# Altium schematic sheet OpenSwitch_Power.SchDoc(OpenSwitch_Power)
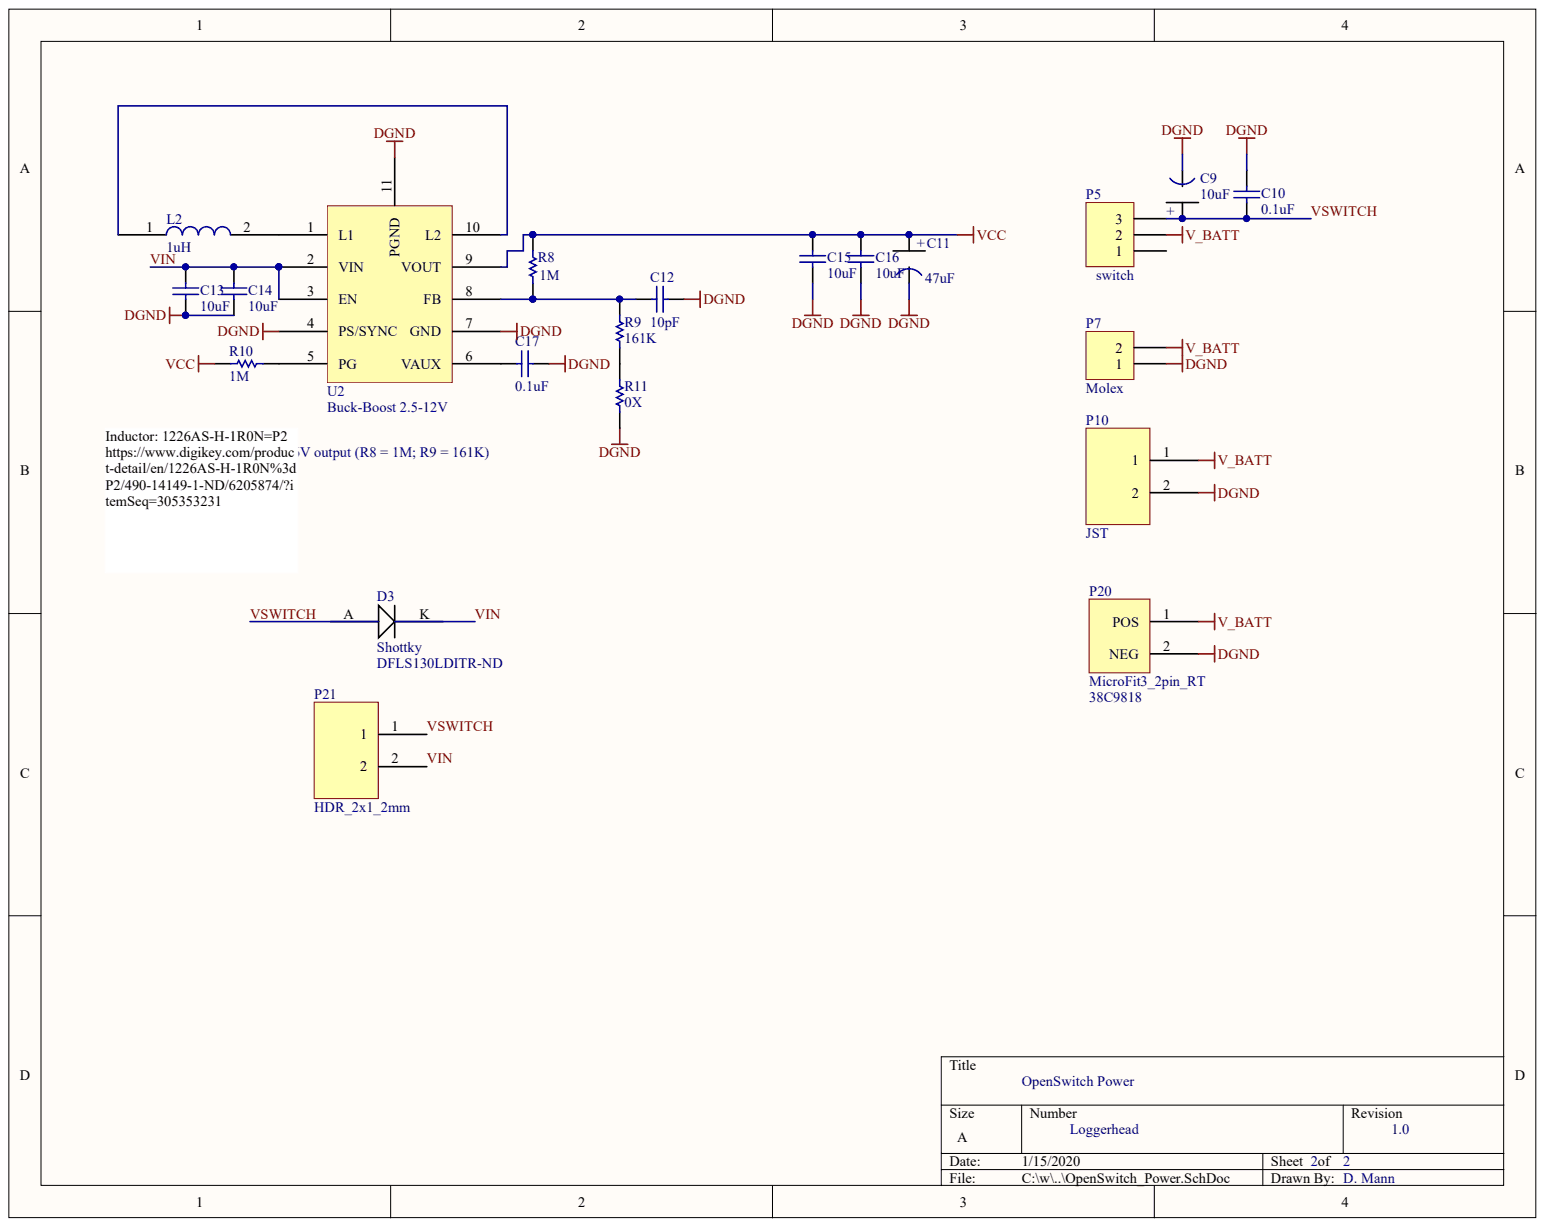
[diagram p0: OpenSwitch_Power.SchDoc]

## Parts
C9  10uF
C10  0.1uF
C11  47uF  value 10uF
C12  10pF
C13  10uF
C14  10uF
C15  10uF
C16  10uF
C17  0.1uF
D3  Shottky  Supplier Part Number 1: DFLS130LDITR-ND
L2  1uH
P5  switch
P7  Molex
P10  JST
P20  MicroFit3_2pin_RT  Supplier Part Number 1: 38C9818
P21  HDR_2x1_2mm
R8  1M
R9  161K
R10  1M
R11  0X
U2  Buck-Boost 2.5-12V

## Nets
DGND: C9.1 C10.2 C11.1 C12.2 C13.1 C14.1 C15.1 C16.1 C17.2 P7.1 P10.2 P20.2 R11.1 U2.4(PS/SYNC) U2.7(GND) U2.11(PGND)
V_BATT: P5.2 P7.2 P10.1 P20.1
VCC: C11.2 C15.2 C16.2 R8.2 R10.1 U2.9(VOUT)
VIN: C13.2 C14.2 D3.K P21.2 U2.2(VIN) U2.3(EN)
VSWITCH: C9.2 C10.1 D3.A P5.3 P21.1
NetC12_1: C12.1 R8.1 R9.2 U2.8(FB)
NetC17_1: C17.1 U2.6(VAUX)
NetL2_1: L2.1 U2.10(L2)
NetL2_2: L2.2 U2.1(L1)
NetR9_1: R9.1 R11.2
NetR10_2: R10.2 U2.5(PG)

Not connected: P5 1

## Library data of the parts
C10 C13-C17 = (no symbol name): Note: Footprint corresponds to dimensions for bulk cassette packaging. This case size has tightened tolerances.; Published: 17-Jul-2002; LatestRevisionDate: 19-Mar-2004; LatestRevisionNote: Silkscreen size reduced.; DatasheetDocument: Mar-2001; PackageDocument: Mar-2001; ComponentLink1Description: Manufacturer Link; ComponentLink1URL: http://www.kemet.com/; ComponentLink2Description: Datasheet; ComponentLink2URL: http://www.kemet.com/kemet/web/homepage/kechome.nsf/vapubfilesname/F3102TA.pdf/$file/F3102TA.pdf; Publisher: Altium Limited
  C10 C17: PackageReference: 0402; Code_JEITA: 0402; Code_IPC: 1005 [0402]; Value: 0.1uF
  C13-C16: PackageReference: 0603; Code_JEITA: 0603; Code_IPC: 1608 [0603]
C12 = (no symbol name): Note: Footprint corresponds to dimensions for bulk cassette packaging. This case size has tightened tolerances.; Value: 0.1uF; Published: 17-Jul-2002; LatestRevisionDate: 19-Mar-2004; LatestRevisionNote: Silkscreen size reduced.; DatasheetDocument: Mar-2001; PackageDocument: Mar-2001; PackageReference: 0402; Code_JEITA: 0402; Code_IPC: 1005 [0402]; ComponentLink1Description: Manufacturer Link; ComponentLink1URL: http://www.kemet.com/; ComponentLink2Description: Datasheet; ComponentLink2URL: http://www.kemet.com/kemet/web/homepage/kechome.nsf/vapubfilesname/F3102TA.pdf/$file/F3102TA.pdf; Publisher: Altium Limited
C9 C11 D3 L2 P5 P7 P10 P20 P21 R8-R11 U2 = (no symbol name)
  C9: Value: 10uF
  D3: Supplier 1: Digi-Key
  L2: Supplier 1: Mouser
  P5 P7: LatestRevisionDate: 17-Jul-2002; LatestRevisionNote: Re-released for DXP Platform.
  P20: Supplier 1: Newark
  R8-R11: Published: 17-Dec-2003; LatestRevisionDate: 19-Mar-2004; LatestRevisionNote: Silkscreen size reduced.; PackageDocument: Mar-2003; PackageReference: 3-0603; Code_IPC: 1608 [0603]; ComponentLink1Description: Manufacturer Link; ComponentLink1URL: http://www.panasonic.com/industrial/components/resistive_products.htm; ComponentLink2Description: Datasheet; ComponentLink2URL: http://www.panasonic.com/industrial/components/pdf/AOA0000CE2.pdf
  U2: Supplier 1: Newark; Supplier Part Number 1: 82T9733
  P5 P7 R8-R11: Publisher: Altium Limited
